# Altium schematic sheet Arduino.SchDoc
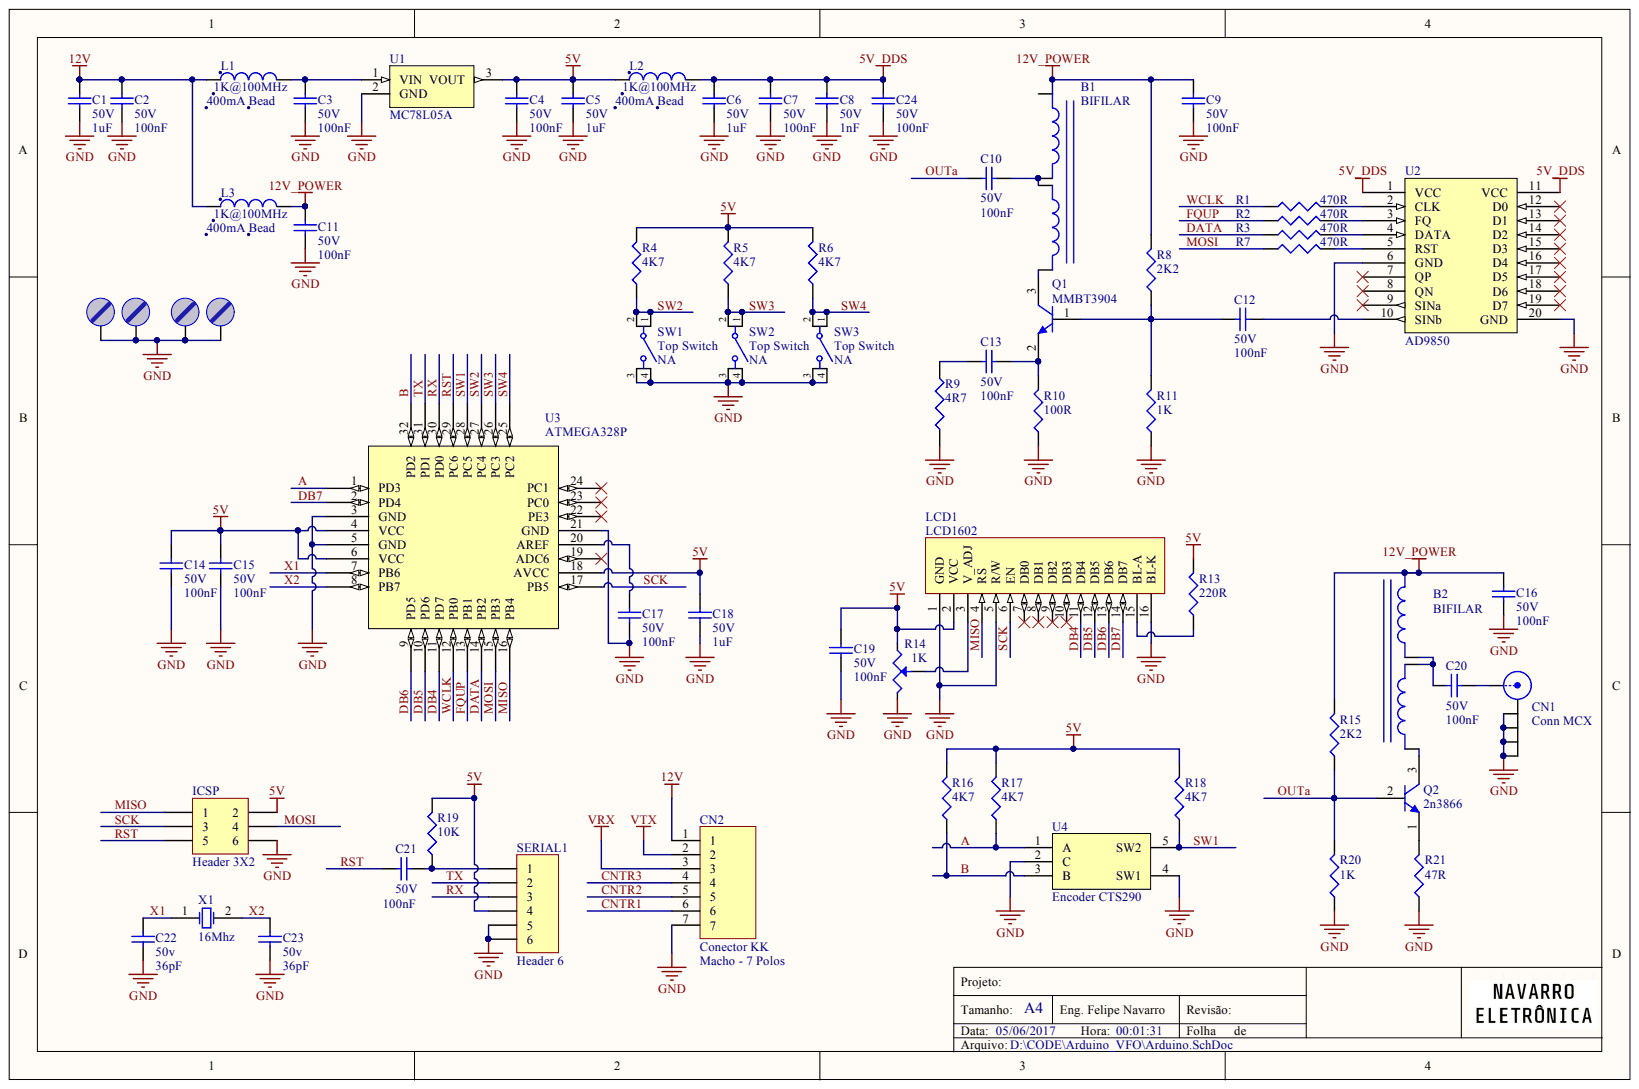
[diagram p0: Arduino.SchDoc]

## Parts
B1  BIFILAR  [Toroid Bifilar]
B2  BIFILAR  [Toroid Bifilar]
C1  value 1uF  Tensão: 50V  [CC0603]  (hidden comment: Capacitor Cerâmico)
C2  value 100nF  Tensão: 50V  [CC0603]  (hidden comment: Capacitor Cerâmico)
C3  value 100nF  Tensão: 50V  [CC0603]  (hidden comment: Capacitor Cerâmico)
C4  value 100nF  Tensão: 50V  [CC0603]  (hidden comment: Capacitor Cerâmico)
C5  value 1uF  Tensão: 50V  [CC0603]  (hidden comment: Capacitor Cerâmico)
C6  value 1uF  Tensão: 50V  [CC0603]  (hidden comment: Capacitor Cerâmico)
C7  value 100nF  Tensão: 50V  [CC0603]  (hidden comment: Capacitor Cerâmico)
C8  value 1nF  Tensão: 50V  [CC0603]  (hidden comment: Capacitor Cerâmico)
C9  value 100nF  Tensão: 50V  [CC0603]  (hidden comment: Capacitor Cerâmico)
C10  value 100nF  Tensão: 50V  [CC0603]  (hidden comment: Capacitor Cerâmico)
C11  value 100nF  Tensão: 50V  [CC0603]  (hidden comment: Capacitor Cerâmico)
C12  value 100nF  Tensão: 50V  [CC0603]  (hidden comment: Capacitor Cerâmico)
C13  value 100nF  Tensão: 50V  [CC0603]  (hidden comment: Capacitor Cerâmico)
C14  value 100nF  Tensão: 50V  [CC0603]  (hidden comment: Capacitor Cerâmico)
C15  value 100nF  Tensão: 50V  [CC0603]  (hidden comment: Capacitor Cerâmico)
C16  value 100nF  Tensão: 50V  [CC0603]  (hidden comment: Capacitor Cerâmico)
C17  value 100nF  Tensão: 50V  [CC0603]  (hidden comment: Capacitor Cerâmico)
C18  value 1uF  Tensão: 50V  [CC0603]  (hidden comment: Capacitor Cerâmico)
C19  value 100nF  Tensão: 50V  [CC0603]  (hidden comment: Capacitor Cerâmico)
C20  value 100nF  Tensão: 50V  [CC0603]  (hidden comment: Capacitor Cerâmico)
C21  value 100nF  Tensão: 50V  [CC0603]  (hidden comment: Capacitor Cerâmico)
C22  value 36pF  Tensão: 50v  [CC0603]  (hidden comment: Capacitor Cerâmico)
C23  value 36pF  Tensão: 50v  [CC0603]  (hidden comment: Capacitor Cerâmico)
C24  value 100nF  Tensão: 50V  [CC0603]  (hidden comment: Capacitor Cerâmico)
CN1  Conn MCX  [CONN MCX MOLEX]
CN2  Conector KK  value Macho - 7 Polos  [CONN HDR7-POL P.100]
ICSP  Header 3X2  [CONN HDR2X3]
L1  Bead  value 1K@100MHz  Corrente: 400mA  [CC0603]
L2  Bead  value 1K@100MHz  Corrente: 400mA  [CC0603]
L3  Bead  value 1K@100MHz  Corrente: 400mA  [CC0603]
LCD1  LCD1602  [LCD-1602]
M1  Furo de Fixação  [Furo_M3]  (value not drawn)  (no BOM)
M2  Furo de Fixação  [Furo_M3]  (value not drawn)  (no BOM)
M3  Furo de Fixação  [Furo_M3]  (value not drawn)  (no BOM)
M4  Furo de Fixação  [Furo_M3]  (value not drawn)  (no BOM)
Q1  MMBT3904  [SOT-23-3N]
Q2  2n3866  [TO-92R]
R1  value 470R  [CC0603]  (hidden comment: Resistor)
R2  value 470R  [CC0603]  (hidden comment: Resistor)
R3  value 470R  [CC0603]  (hidden comment: Resistor)
R4  value 4K7  [CC0603]  (hidden comment: Resistor)
R5  value 4K7  [CC0603]  (hidden comment: Resistor)
R6  value 4K7  [CC0603]  (hidden comment: Resistor)
R7  value 470R  [CC0603]  (hidden comment: Resistor)
R8  value 2K2  [CC0603]  (hidden comment: Resistor)
R9  value 4R7  [CC0603]  (hidden comment: Resistor)
R10  value 100R  [CC0603]  (hidden comment: Resistor)
R11  value 1K  [CC0603]  (hidden comment: Resistor)
R13  value 220R  [CC0603]  (hidden comment: Resistor)
R14  value 1K  [POT]  (hidden comment: Potenciômetro)
R15  value 2K2  [CC0603]  (hidden comment: Resistor)
R16  value 4K7  [CC0603]  (hidden comment: Resistor)
R17  value 4K7  [CC0603]  (hidden comment: Resistor)
R18  value 4K7  [CC0603]  (hidden comment: Resistor)
R19  value 10K  [CC0603]  (hidden comment: Resistor)
R20  value 1K  [CC0603]  (hidden comment: Resistor)
R21  value 47R  [CC0603]  (hidden comment: Resistor)
SERIAL1  Header 6  [CONN HDR1X6]
SW1  Top Switch  value NA  [SWITCH-TACTIL_6x6]
SW2  Top Switch  value NA  [SWITCH-TACTIL_6x6]
SW3  Top Switch  value NA  [SWITCH-TACTIL_6x6]
U1  MC78L05A  [SOT-89-3N]
U2  AD9850  [AD9850]
U3  ATMEGA328P  [TQFP-32N]
U4  Encoder CTS290  [ENCODER - CTS 290]
X1  16Mhz  [HC/49US]

## Nets
5V: C4.2 C5.2 C14.2 C15.2 C18.2 C19.2 ICSP.2 L2.1 LCD1.2(VCC) R4.2 R5.2 R6.2 R13.2 R14.1 R16.2 R17.2 R18.2 R19.2 SERIAL1.4 U1.3 U3.4(VCC) U3.6(VCC) U3.18(AVCC)
5V_DDS: C6.2 C7.2 C8.2 C24.2 L2.2 U2.1(VCC) U2.11(VCC)
12V: C1.2 C2.2 CN2.1 L1.1 L3.1
12V_POWER: B1.1 B2.1 C9.2 C11.2 C16.2 L3.2 R8.2 R15.2
GND: C1.1 C2.1 C3.1 C4.1 C5.1 C6.1 C7.1 C8.1 C9.1 C11.1 C14.1 C15.1 C16.1 C17.1 C18.1 C19.1 C22.1 C23.1 C24.1 CN1.2 CN1.3 CN1.4 CN1.5 CN2.7 ICSP.6 LCD1.1(GND) LCD1.5(R/W) LCD1.16(BL-K) M1.X M2.X M3.X M4.X R9.1 R10.1 R11.1 R14.3 R20.1 R21.1 SERIAL1.5 SERIAL1.6 SW1.3 SW1.4 SW2.3 SW2.4 SW3.3 SW3.4 U1.2 U2.6(GND) U2.20(GND) U3.3(GND) U3.5(GND) U3.21(GND) U4.2(C) U4.4(SW1)
VRX: CN2.3
VTX: CN2.2
A: R17.1 U3.1(PD3) U4.1(A)
B: R16.1 U3.32(PD2) U4.3(B)
CNTR1: CN2.6
CNTR2: CN2.5
CNTR3: CN2.4
DATA: R3.1 U3.14(PB2)
DB4: LCD1.11(DB4) U3.11(PD7)
DB5: LCD1.12(DB5) U3.10(PD6)
DB6: LCD1.13(DB6) U3.9(PD5)
DB7: LCD1.14(DB7) U3.2(PD4)
FQUP: R2.1 U3.13(PB1)
MISO: ICSP.1 LCD1.4(RS) U3.16(PB4)
MOSI: ICSP.4 R7.1 U3.15(PB3)
OUTA: C10.2 Q2.2 R15.1 R20.2
RST: C21.2 ICSP.5 U3.29(PC6)
RX: SERIAL1.3 U3.30(PD0)
SCK: ICSP.3 LCD1.6(EN) U3.17(PB5)
SW1: R18.1 U3.28(PC5) U4.5(SW2)
SW2: R4.1 SW1.1 SW1.2 U3.27(PC4)
SW3: R5.1 SW2.1 SW2.2 U3.26(PC3)
SW4: R6.1 SW3.1 SW3.2 U3.25(PC2)
TX: SERIAL1.2 U3.31(PD1)
WCLK: R1.1 U3.12(PB0)
X1: C22.2 U3.7(PB6) X1.1
X2: C23.2 U3.8(PB7) X1.2
NetB1_2: B1.2 B1.3 C10.1
NetB1_4: B1.4 Q1.3
NetB2_2: B2.2 B2.3 C20.2
NetB2_4: B2.4 Q2.3
NetC3_2: C3.2 L1.2 U1.1
NetC12_1: C12.1 U2.10(SINb)
NetC12_2: C12.2 Q1.1 R8.1 R11.2
NetC13_1: C13.1 R9.2
NetC13_2: C13.2 Q1.2 R10.2
NetC17_2: C17.2 U3.20(AREF)
NetC20_1: C20.1 CN1.1
NetC21_1: C21.1 R19.1 SERIAL1.1
NetLCD1_3: LCD1.3(V_ADJ) R14.2
NetLCD1_15: LCD1.15(BL-A) R13.1
NetQ2_1: Q2.1 R21.2
NetR1_2: R1.2 U2.2(CLK)
NetR2_2: R2.2 U2.3(FQ)
NetR3_2: R3.2 U2.4(DATA)
NetR7_2: R7.2 U2.5(RST)

Not connected: LCD1 7(DB0) 8(DB1) 9(DB2) 10(DB3); U2 7(QP) 8(QN) 9(SINa) 12(D0) 13(D1) 14(D2) 15(D3) 16(D4) 17(D5) 18(D6) 19(D7); U3 19(ADC6) 22(PE3) 23(PC0) 24(PC1)

## Library data of the parts
B1 B2 = Toroid Bifilar: ChinesePrice: 0,00000; Chinês: ----; Component Kind: Standard; ComponentLink1Description: Datasheet; Description: Toroid Bifilar; Simulation: IDEALTRANS; Substituição: 00/00/0000; Supplier 1: Digi-Key; ComponentLink1URL: D:\Tecnoclade\Datasheet\!DataMissing.pdf; Library Name: NavarroIND.SchLib
C1 C5 C6 C18 = Cap 1uF 50V 0603 X5R: Capacitor (Semiconductor SIM Model); ChinesePrice: 0,01100; Chinês: Local; Corrente: *A; Obs: X5R; Potência: *W; Tolerância: ±10%; Component Kind: Standard; ComponentLink1Description: Datasheet; Simulation: CAP; Supplier 1: Digi-Key; Supplier Part Number 1: 587-2400-1-ND; ComponentLink1URL: D:\Tecnoclade\Datasheet\!DataMissing.pdf; Library Name: NavarroCAP.SchLib
C2-C4 C7 C9-C17 C19 C21 C24 = Cap 100nF 50V 0603 X7R: Capacitor (Semiconductor SIM Model); ChinesePrice: 0,00250; Chinês: Local; Corrente: *A; Obs: X7R; Potência: *W; Tolerância: ±10%; Component Kind: Standard; ComponentLink1Description: Datasheet; Simulation: CAP; Supplier 1: Digi-Key; Supplier Part Number 1: 1276-1000-1-ND; ComponentLink1URL: D:\Tecnoclade\Datasheet\!DataMissing.pdf; Library Name: NavarroCAP.SchLib
C8 = Cap 1nF 50V 0603 NP0: Capacitor (Semiconductor SIM Model); ChinesePrice: 0,00700; Chinês: Local; Corrente: *A; Obs: NP0; Potência: *W; Tolerância: ±5%; Component Kind: Standard; ComponentLink1Description: Datasheet; Simulation: CAP; Supplier 1: Digi-Key; Supplier Part Number 1: 490-1451-1-ND; ComponentLink1URL: D:\Tecnoclade\Datasheet\!DataMissing.pdf; Library Name: NavarroCAP.SchLib
C20 = Cap 100nF 50V 0603 X7R: Capacitor (Semiconductor SIM Model); ChinesePrice: 0,00250; Chinês: Local; Corrente: *A; Obs: X7R; Potência: *W; Tolerância: ±10%; Value: 100nF; Component Kind: Standard; ComponentLink1Description: Datasheet; Simulation: CAP; Supplier 1: Digi-Key; Supplier Part Number 1: 1276-1000-1-ND; ComponentLink1URL: D:\Tecnoclade\Datasheet\!DataMissing.pdf; Library Name: NavarroCAP.SchLib
C22 C23 = Cap - $Val $V $Footprint $Classe_1: Capacitor (Semiconductor SIM Model); ChinesePrice: 0,00000; Chinês: ----; Corrente: *A; Potência: *W; Tolerância: ±*%; Component Kind: Standard; ComponentLink1Description: Datasheet; Simulation: CAP; Supplier 1: Digi-Key; Supplier Part Number 1: 1276-1187-1-ND; ComponentLink1URL: D:\Tecnoclade\Datasheet\!DataMissing.pdf; Library Name: NavarroCAP.SchLib
CN1 = Conn MCX: Conector MCX; ChinesePrice: 0,00000; Chinês: ----; Value: SMA; Component Kind: Standard; ComponentLink1Description: Datasheet; Supplier 1: Digi-Key; Supplier Part Number 1: WM5541-ND; ComponentLink1URL: D:\Tecnoclade\Datasheet\!DataMissing.pdf; Library Name: NavarroCON.SchLib
CN2 = KK 7P Macho-V P2.54mm: ChinesePrice: 0,00000; Chinês: ----; Component Kind: Standard; ComponentLink1Description: Datasheet; Description: Conector KK; Supplier 1: Digi-Key; Supplier Part Number 1: A19472-ND; ComponentLink1URL: D:\Tecnoclade\Datasheet\!DataMissing.pdf; Library Name: NavarroCON.SchLib
ICSP = Header 3X2: Header, 5-Pin, Dual row; ChinesePrice: 0,00000; Chinês: ----; Obs: Barra dupla de 40 pinos - ATENÇÃO; Value: Macho; Component Kind: Standard; ComponentLink1Description: Datasheet; Supplier 1: Digi-Key; Supplier Part Number 1: 609-3724-ND; ComponentLink1URL: D:\Tecnoclade\Datasheet\!DataMissing.pdf; Library Name: NavarroCON.SchLib
L1-L3 = Bd 1K@100MHz 0603 400mA: ChinesePrice: 0,00000; Chinês: -----; Obs: Ferrite (0R5); Tolerância: ±25%; Component Kind: Standard; ComponentLink1Description: Datasheet; Description: Bead; Simulation: INDUCTOR; Substituição: 27/06/2014; Supplier 1: Digi-Key; Supplier Part Number 1: 445-1550-1-ND; ComponentLink1URL: D:\Tecnoclade\Datasheet\!DataMissing.pdf; Library Name: NavarroIND.SchLib
LCD1 = LCD1602: LCD Generico 16 caracteres X 2 linhas; ChinesePrice: 0,00000; Chinês: ----; Obs: BackLigth = 3V ~ 11V (5V recomendado); Tensão: -0V3 ~ 7V; Value: Display LCD de LED; Component Kind: Standard; ComponentLink1Description: Datasheet; Supplier 1: Digi-Key; Supplier Part Number 1: --LCD; ComponentLink1URL: D:\Tecnoclade\Datasheet\; Library Name: Navarro.SchLib
M1-M4 = Parafuso: Furo para parafuso; ChinesePrice: 0,00000; Chinês: ----; Value: M3; ComponentLink1Description: Datasheet; Supplier 1: Digi-Key; Supplier Part Number 1: --Del; ComponentLink1URL: D:\Tecnoclade\Datasheet\!DataMissing.pdf; Library Name: Tecnoclade.SchLib
Q1 = TRS MMBT3904 40V 200mA SOT-23-3N: NPN General Purpose Amplifier; ChinesePrice: 0,00000; Chinês: ----; Corrente: 600mA; Potência: 350mW; Tensão: 40V; Value: 300MHz; Component Kind: Standard; ComponentLink1Description: Datasheet; Simulation: 2N3904; Supplier 1: Digi-Key; Supplier Part Number 1: 568-4510-1-ND; ComponentLink1URL: D:\Tecnoclade\Datasheet\!DataMissing.pdf; Library Name: NavarroSEMI.SchLib
Q2 = TRS BC108 TO-18: NPN General Purpose Amplifier; ChinesePrice: 0,00000; Chinês: ----; Corrente: 200mA; Potência: 250mW; Tensão: 40V; Value: 300MHz; Component Kind: Standard; ComponentLink1Description: Datasheet; Simulation: 2N3904; Supplier 1: Digi-Key; ComponentLink1URL: D:\Tecnoclade\Datasheet\!DataMissing.pdf; Library Name: NavarroSEMI.SchLib
R1-R3 R7 = Res 470R 5% 0603 1/10W: ChinesePrice: 0,00000; Chinês: ----; Corrente: *A; Obs: ±200ppm/ºC; Potência: 1/10W; Tensão: *V; Tolerância: ±5%; Component Kind: Standard; ComponentLink1Description: Datasheet; Description: Resistor; Simulation: RESISTOR; Supplier 1: Digi-Key; Supplier Part Number 1: RMCF0603JT470RCT-ND; ComponentLink1URL: D:\Tecnoclade\Datasheet\!DataMissing.pdf; Library Name: NavarroRES.SchLib
R4-R6 R16-R18 = Res 4K7 1% 0603 1/10W: ChinesePrice: 0,00000; Chinês: ----; Corrente: *A; Obs: ±100ppm/ºC; Potência: 1/10W; Tensão: *V; Tolerância: ±1%; Component Kind: Standard; ComponentLink1Description: Datasheet; Description: Resistor; Simulation: RESISTOR; Supplier 1: Digi-Key; Supplier Part Number 1: RMCF0603FT4K70CT-ND; ComponentLink1URL: D:\Tecnoclade\Datasheet\!DataMissing.pdf; Library Name: NavarroRES.SchLib
R8 R15 = Res 2K2 5% 0603 1/10W: ChinesePrice: 0,00000; Chinês: ----; Corrente: *A; Obs: ±200ºC/ppm; Potência: 1/10W; Tensão: *V; Tolerância: ±5%; Component Kind: Standard; ComponentLink1Description: Datasheet; Description: Resistor; Simulation: RESISTOR; Supplier 1: Digi-Key; Supplier Part Number 1: RMCF0603JT2K20CT-ND; ComponentLink1URL: D:\Tecnoclade\Datasheet\!DataMissing.pdf; Library Name: NavarroRES.SchLib
R9 = Res 4R7 1% 0603 1/10W: ChinesePrice: 0,00000; Chinês: ----; Corrente: *A; Potência: 1/10W; Tensão: *V; Tolerância: ±*%; Component Kind: Standard; ComponentLink1Description: Datasheet; Description: Resistor; Simulation: RESISTOR; Supplier 1: Digi-Key; Supplier Part Number 1: 311-4.7GRCT-ND; ComponentLink1URL: D:\Tecnoclade\Datasheet\!DataMissing.pdf; Library Name: NavarroRES.SchLib
R10 = Res 100R 5% 0603 1/10W: ChinesePrice: 0,00080; Chinês: Local; Corrente: *A; Potência: 1/10W; Tensão: *V; Tolerância: ±5%; Component Kind: Standard; ComponentLink1Description: Datasheet; Description: Resistor; Simulation: RESISTOR; Supplier 1: Digi-Key; Supplier Part Number 1: RMCF0603JT100RCT-ND; ComponentLink1URL: D:\Tecnoclade\Datasheet\!DataMissing.pdf; Library Name: NavarroRES.SchLib
R11 R20 = Res 1K 1% 0603 1/10W: ChinesePrice: 0,00089; Chinês: Local; Corrente: *A; Potência: 1/10W; Tensão: *V; Tolerância: ±1%; Component Kind: Standard; ComponentLink1Description: Datasheet; Description: Resistor; Simulation: RESISTOR; Supplier 1: Digi-Key; Supplier Part Number 1: RMCF0603FT1K00CT-ND; ComponentLink1URL: D:\Tecnoclade\Datasheet\!DataMissing.pdf; Library Name: NavarroRES.SchLib
R13 = Res 220R 1% 0603 1/10W: ChinesePrice: 0,00000; Chinês: ----; Corrente: *A; Obs: ±100ppm/ºC; Potência: 1/10W; Tensão: *V; Tolerância: ±1%; Component Kind: Standard; ComponentLink1Description: Datasheet; Description: Resistor; Simulation: RESISTOR; Supplier 1: Digi-Key; Supplier Part Number 1: RMCF0603FT220RCT-ND; ComponentLink1URL: D:\Tecnoclade\Datasheet\!DataMissing.pdf; Library Name: NavarroRES.SchLib
R14 = Res Pot: Potenciometro; ChinesePrice: 0,00000; Chinês: ----; Corrente: *A; Potência: *W; Tensão: *V; Tolerância: ±*%; Component Kind: Standard; ComponentLink1Description: Datasheet; Supplier 1: Digi-Key; Supplier Part Number 1: --Del; ComponentLink1URL: D:\Tecnoclade\Datasheet\!DataMissing.pdf; Library Name: NavarroRES.SchLib
R19 = Res 10K 1% 0603 1/10W: ChinesePrice: 0,00089; Chinês: Local; Corrente: *A; Potência: 1/10W; Tensão: *V; Tolerância: ±1%; Component Kind: Standard; ComponentLink1Description: Datasheet; Description: Resistor; Simulation: RESISTOR; Supplier 1: Digi-Key; Supplier Part Number 1: P10.0KHCT-ND; ComponentLink1URL: D:\Tecnoclade\Datasheet\!DataMissing.pdf; Library Name: NavarroRES.SchLib
R21 = Res 47K 1% 0603 1/10W: ChinesePrice: 0,00089; Chinês: Local; Corrente: *A; Obs: ±100ppm/ºC; Potência: 1/10W; Tensão: *V; Tolerância: ±1%; Component Kind: Standard; ComponentLink1Description: Datasheet; Description: Resistor; Simulation: RESISTOR; Supplier 1: Digi-Key; ComponentLink1URL: D:\Tecnoclade\Datasheet\!DataMissing.pdf; Library Name: NavarroRES.SchLib
SERIAL1 = Header 6: Header, 6-Pin; ChinesePrice: 0,10000; Chinês: Proved - Local; Value: Barra de 40 pinos - ATENÇÃO; Component Kind: Standard; ComponentLink1Description: Datasheet; Supplier 1: Digi-Key; Supplier Part Number 1: A26509-40-ND; ComponentLink1URL: D:\Tecnoclade\Datasheet\!DataMissing.pdf; Library Name: NavarroCON.SchLib
SW1-SW3 = SW-SPST Tactil: Single-Pole, Single-Throw Switch; ChinesePrice: 0,03000; Chinês: Local; Corrente: 50mA; Obs: Atuador = 13mm(h) X 6mm (dia); Tensão: 12V; Component Kind: Standard; ComponentLink1Description: Datasheet; Supplier 1: Digi-Key; Supplier Part Number 1: 450-1642-ND; ComponentLink1URL: D:\Tecnoclade\Datasheet\!DataMissing.pdf; Library Name: Tecnoclade.SchLib
U1 = MC78L05: Regulador Linear 5V; ChinesePrice: 0,00000; Chinês: -----; Corrente: 100mA; Component Kind: Standard; ComponentLink1Description: Datasheet; Supplier 1: Digi-Key; Supplier Part Number 1: MC78L05ACHXCT-ND; Tensão: 5V; ComponentLink1URL: D:\Tecnoclade\Datasheet\; Library Name: Navarro.SchLib
U2 = AD9850: DDS AD9850 Module; ChinesePrice: 0,00000; Chinês: ----; Component Kind: Standard; ComponentLink1Description: Datasheet; Supplier 1: Digi-Key; ComponentLink1URL: D:\Tecnoclade\Datasheet\; Library Name: Navarro.SchLib
U3 = ATMEGA328P: IC MCU 32KB FLASH 20MHZ 32TQFP; ChinesePrice: 0,00000; Chinês: ----; Component Kind: Standard; ComponentLink1Description: Datasheet; Supplier 1: Digi-Key; Supplier Part Number 1: ATMEGA328P-AN-ND; ComponentLink1URL: D:\Tecnoclade\Datasheet\; Library Name: Navarro.SchLib
U4 = Encoder CTS 290: Memória; ChinesePrice: 1,94000; Chinês: Local; Component Kind: Standard; ComponentLink1Description: Datasheet; Supplier 1: Digi-Key; Supplier Part Number 1: CT3006-ND; ComponentLink1URL: D:\Tecnoclade\Datasheet\!DataMissing.pdf; Library Name: Navarro.SchLib
X1 = XTAL: Crystal Oscillator; ChinesePrice: 0,00000; Chinês: ----; Value: 20pF/40R; Component Kind: Standard; Simulation: XTAL; Supplier 1: Digi-Key; Supplier Part Number 1: 535-10226-1-ND; Library Name: Navarro.SchLib
